# Altium schematic sheet MCU.SchDoc(MCU)
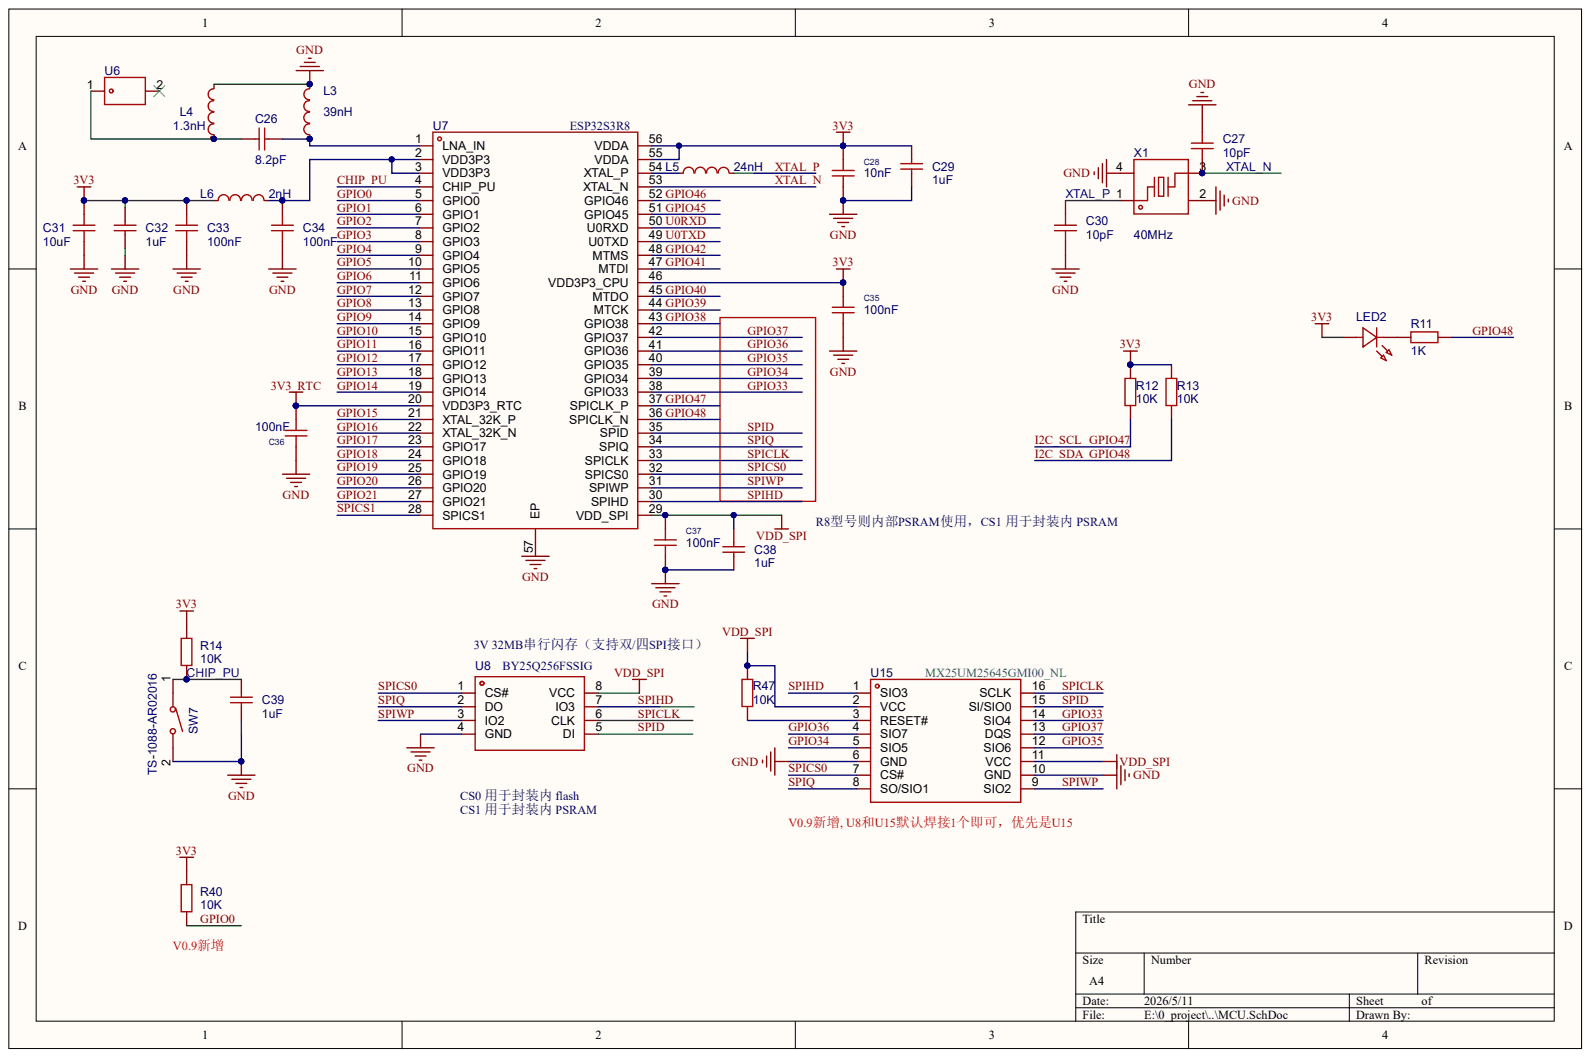
[diagram p0: MCU.SchDoc]

## Parts
C26  8.2pF
C27  10pF
C28  value 10nF  (hidden comment: 100nF)
C29  1uF
C30  10pF
C31  10uF
C32  1uF
C33  100nF
C34  100nF
C35  100nF
C36  100nF
C37  100nF
C38  1uF
C39  1uF
L3  39nH
L4  1.3nH
L5  24nH
L6  2nH
LED2  XL-1005UGC  (value not drawn)
R11  1K
R12  10K
R13  10K
R14  10K
R40  10K
R47  10K
SW7  TS-1088-AR02016
U6  RFANT5220110A0T  (value not drawn)
U7  ESP32S3R8
U8  BY25Q256FSSIG
U15  MX25UM25645GMI00_NL
X1  40MHz

## Nets
3V3: C28.2 C29.2 C31.2 C32.2 C33.2 C35.2 L6.1 LED2.2 R12.2 R13.2 R14.2 R40.2 U7.46(VDD3P3_CPU) U7.55(VDDA) U7.56(VDDA)
3V3_RTC: C36.2 U7.20(VDD3P3_RTC)
GND: C27.2 C28.1 C29.1 C30.1 C31.1 C32.1 C33.1 C34.1 C35.1 C36.1 C37.1 C38.1 C39.1 L3.2 L4.2 SW7.2 U7.57(EP) U8.4(GND) U15.6(GND) U15.10(GND) X1.2 X1.4
VDD_SPI: C37.2 C38.2 R47.2 U7.29(VDD_SPI) U8.8(VCC) U15.2(VCC) U15.11(VCC)
CHIP_PU: C39.2 R14.1 SW7.1 U7.4(CHIP_PU)
GPIO0: R40.1 U7.5(GPIO0)
GPIO1: U7.6(GPIO1)
GPIO2: U7.7(GPIO2)
GPIO3: U7.8(GPIO3)
GPIO4: U7.9(GPIO4)
GPIO5: U7.10(GPIO5)
GPIO6: U7.11(GPIO6)
GPIO7: U7.12(GPIO7)
GPIO8: U7.13(GPIO8)
GPIO9: U7.14(GPIO9)
GPIO10: U7.15(GPIO10)
GPIO11: U7.16(GPIO11)
GPIO12: U7.17(GPIO12)
GPIO13: U7.18(GPIO13)
GPIO14: U7.19(GPIO14)
GPIO15: U7.21(XTAL_32K_P)
GPIO16: U7.22(XTAL_32K_N)
GPIO17: U7.23(GPIO17)
GPIO18: U7.24(GPIO18)
GPIO19: U7.25(GPIO19)
GPIO20: U7.26(GPIO20)
GPIO21: U7.27(GPIO21)
GPIO33: U7.38(GPIO33) U15.14(SIO4)
GPIO34: U7.39(GPIO34) U15.5(SIO5)
GPIO35: U7.40(GPIO35) U15.12(SIO6)
GPIO36: U7.41(GPIO36) U15.4(SIO7)
GPIO37: U7.42(GPIO37) U15.13(DQS)
GPIO38: U7.43(GPIO38)
GPIO39: U7.44(MTCK)
GPIO40: U7.45(MTDO)
GPIO41: U7.47(MTDI)
GPIO42: U7.48(MTMS)
GPIO45: U7.51(GPIO45)
GPIO46: U7.52(GPIO46)
GPIO47: R12.1 U7.37(SPICLK_P)
GPIO48: R11.2 R13.1 U7.36(SPICLK_N)
SPICLK: U7.33(SPICLK) U8.6(CLK) U15.16(SCLK)
SPICS0: U7.32(SPICS0) U8.1(CS#) U15.7(CS#)
SPICS1: U7.28(SPICS1)
SPID: U7.35(SPID) U8.5(DI) U15.15(SI/SIO0)
SPIHD: U7.30(SPIHD) U8.7(IO3) U15.1(SIO3)
SPIQ: U7.34(SPIQ) U8.2(DO) U15.8(SO/SIO1)
SPIWP: U7.31(SPIWP) U8.3(IO2) U15.9(SIO2)
U0RXD: U7.50(U0RXD)
U0TXD: U7.49(U0TXD)
XTAL_N: C27.1 U7.53(XTAL_N) X1.3
XTAL_P: C30.2 L5.2 X1.1
NetC26_1: C26.1 L4.1 U6.1
NetC26_2: C26.2 L3.1 U7.1(LNA_IN)
NetC34_2: C34.2 L6.2 U7.2(VDD3P3) U7.3(VDD3P3)
NetL5_1: L5.1 U7.54(XTAL_P)
NetLED2_1: LED2.1 R11.1
NetR47_1: R47.1 U15.3(RESET#)

Not connected: U6 2

## Library data of the parts
C26 = (no symbol name): Symbol: 0402CG8R2C500NT; AddInBom: yes; ModelId: 36a51122f27c49738f86b3bfa98863e0; ModelName: C0402_L1.0-W0.5-H0.5; ModelTransform: 39.37,19.685,0,0,0,0,0,0,0; Voltage Rated: 50V; Temperature Coefficient: C0G; Value: 8.2pF; Device: 0402CG8R2C500NT; Supplier: LCSC; Manufacturer Part: 0402CG8R2C500NT; Supplier Part: C1579; Supplier Footprint: 0402; Datasheet: https://atta.szlcsc.com/upload/public/pdf/source/20200305/C486355_26A10D38EF8C2A5C37091D0039909270.pdf; ConvertToPcb: yes; FootprintName: R0402
C27 C30 = (no symbol name): Device: CL05C100JB5NNNC; AddInBom: yes; ModelId: 36a51122f27c49738f86b3bfa98863e0; ModelName: C0402_L1.0-W0.5-H0.5; ModelTransform: 39.37,19.685,0,0,0,0,0,0,0; Voltage Rated: 50V; Temperature Coefficient: C0G; Value: 10pF; Symbol: CL05C100JB5NNNC; SupplierPart: C32949; ManufacturerPart: CL05C100JB5NNNC; Supplier Footprint: 0402; JLCPCB Part Class: Basic Part; Datasheet: https://atta.szlcsc.com/upload/public/pdf/source/20160103/1457707722238.pdf; Supplier: LCSC; ConvertToPcb: yes; FootprintName: R0402
C28 C33-C37 = (no symbol name): Symbol: GRM155R71H104KE14D; AddInBom: yes; ModelId: 36a51122f27c49738f86b3bfa98863e0; ModelName: C0402_L1.0-W0.5-H0.5; ModelTransform: 39.37,19.685,0,0,0,0,0,0,0; Voltage Rated: 50V; Temperature Coefficient: X7R; Device: GRM155R71H104KE14D; Supplier: LCSC; Manufacturer Part: GRM155R71H104KE14D; Capacitance: 100nF; Supplier Part: C77020; Supplier Footprint: 0402; Datasheet: https://atta.szlcsc.com/upload/public/pdf/source/20180326/C77020_39BD49C951FF1AF0DB1F1FAC5AC1E401.pdf; ConvertToPcb: yes; FootprintName: R0402
  C33-C37: Value: 100nF
C29 C32 C38 C39 = (no symbol name): Device: CL05A105KP5NNNC; AddInBom: yes; ModelId: 36a51122f27c49738f86b3bfa98863e0; ModelName: C0402_L1.0-W0.5-H0.5; ModelTransform: 39.37,19.685,0,0,0,0,0,0,0; Voltage Rated: 10V; Temperature Coefficient: X5R; Value: 1uF; Symbol: CL05A105KP5NNNC; SupplierPart: C14445; ManufacturerPart: CL05A105KP5NNNC; Supplier Footprint: 0402; Datasheet: https://atta.szlcsc.com/upload/public/pdf/source/20160103/1457707670698.pdf; Supplier: LCSC; ConvertToPcb: yes; FootprintName: R0402
C31 = (no symbol name): Device: GRM155R61A106ME44D; AddInBom: yes; ModelId: 36a51122f27c49738f86b3bfa98863e0; ModelName: C0402_L1.0-W0.5-H0.5; ModelTransform: 39.37,19.685,0,0,0,0,0,0,0; Voltage Rated: 10V; Temperature Coefficient: X5R; Value: 10uF; Symbol: GRM155R61A106ME44D; SupplierPart: C77000; ManufacturerPart: GRM155R61A106ME44D; Supplier Footprint: 0402; Datasheet: https://atta.szlcsc.com/upload/public/pdf/source/20180530/C77000_4866797CFE3A842CD03C597EBD6AE6CD.pdf; Supplier: LCSC; ConvertToPcb: yes; FootprintName: R0402
L3 = (no symbol name): Symbol: SDCL1005C39NJTDF; AddInBom: yes; ModelId: d8d36575a3234d4790bfa765c88664a7; ModelName: L0402_L1.0-W0.5-H0.5_BEAD; ModelTransform: 39.37,19.685,0,0,0,0,0,0.0005,0; Rated Current: 200mA; Value: 39nH; Device: SDCL1005C39NJTDF; Supplier: LCSC; Manufacturer Part: SDCL1005C39NJTDF; Supplier Part: C26443; LCSC Part Name: 39nH; Supplier Footprint: 0402; ConvertToPcb: yes; FootprintName: R0402
L4 = (no symbol name): Symbol: SDCL1005C1N3STDF; AddInBom: yes; ModelId: f6d1952e61d54696b3449b2fc42ef9b7; ModelName: L0402-RD; ModelTransform: 40.1574,20.4724,0,0,0,0,0,0,0; Rated Current: 400mA; Q @ Freq: 8@100MHz; Frequency - Self Resonant: 10GHz; Value: 1.3nH; Device: SDCL1005C1N3STDF; Supplier: LCSC; Supplier Part: C279756; Manufacturer Part: SDCL1005C1N3STDF; LCSC Part Name: 1.3nH; Supplier Footprint: 0402; Datasheet: https://atta.szlcsc.com/upload/public/pdf/source/20231027/6126A3AAC5912C34388756BE22DEE00C.pdf; ConvertToPcb: yes; FootprintName: R0402; Tolerance: -; Saturation Current (Isat): -; Ratings: -
L5 = (no symbol name): Device: SDCL1005C24NJTDF; AddInBom: yes; ModelId: f6d1952e61d54696b3449b2fc42ef9b7; ModelName: L0402-RD; ModelTransform: 40.1574,20.4724,0,0,0,0,0,0,0; Value: 24nH; Symbol: SDCL1005C24NJTDF; SupplierPart: C74301; ManufacturerPart: SDCL1005C24NJTDF; Supplier Footprint: 0402; Datasheet: https://atta.szlcsc.com/upload/public/pdf/source/20210916/2389196E6DC4CA1121B2D3610B126F46.pdf; Supplier: LCSC; ConvertToPcb: yes; FootprintName: R0402; Rated Current: -; Saturation Current (Isat): -; DC Resistance (DCR): -; Q @ Freq: -; Frequency - Self Resonant: -; Ratings: -
L6 = (no symbol name): Manufacturer: TDK; Device: MLG1005S2N0BT000; AddInBom: yes; ModelId: d8d36575a3234d4790bfa765c88664a7; ModelName: L0402_L1.0-W0.5-H0.5_BEAD; ModelTransform: 39.37,19.685,0,0,0,0,0,0.0005,0; Rated Current: 900mA; Q @ Freq: 7@100MHz; Frequency - Self Resonant: 7.5GHz; Value: 2nH; Symbol: MLG1005S2N0BT000; SupplierPart: C76769; ManufacturerPart: MLG1005S2N0BT000; Supplier Footprint: 0402; JLCPCB Part Class: Extended Part; Datasheet: https://atta.szlcsc.com/upload/public/pdf/source/20160918/1474166917976.pdf; Supplier: LCSC; ConvertToPcb: yes; FootprintName: R0402; Tolerance: -; Saturation Current (Isat): -; Ratings: -
LED2 = (no symbol name): Symbol: XL-1005UGC_C2856703; AddInBom: yes; ModelId: 080bb9bd520f4b34ac21e218e7b036d6; ModelName: LED0402_L1.0-W0.5-H0.2; ModelTransform: 40.1574,19.685,0,0,0,0,0,-0.0005,0; Dominant Wavelength: 516nm; Peak Wavelength: 531nm; Forward Current: 25mA; Power Dissipation: 80mW; Device: XL-1005UGC; SupplierPart: C2856703; ManufacturerPart: XL-1005UGC; Supplier Footprint: 0402; JLCPCB Part Class: Extended Part; Datasheet: https://atta.szlcsc.com/upload/public/pdf/source/20221102/FF8D6BC2225363A60A01D46BB802F217.pdf; Supplier: LCSC; ConvertToPcb: yes; FootprintName: LED0402-RD_GREEN; Color Temperature: -
R11 = (no symbol name): Device: 0402WGF1502TCE; AddInBom: yes; ModelId: 671aefced39d4c318694bba8bd8efd39; ModelName: R0402_L1.0-W0.5-H0.5; ModelTransform: 39.37,19.685,0,0,0,0,0,0.0005,0; Power(Watts): 62.5mW; Overload Voltage (Max): 50V; Value: 1K; Symbol: 0402WGF1502TCE; SupplierPart: C25756; ManufacturerPart: 0402WGF1502TCE; Supplier Footprint: 0402; JLCPCB Part Class: Basic Part; Datasheet: https://atta.szlcsc.com/upload/public/pdf/source/20200306/C422600_1E6D84923E4A46A82E41ADD87F860B5C.pdf; Supplier: LCSC; ConvertToPcb: yes; FootprintName: R0402
R12-R14 R40 R47 = (no symbol name): Device: 0402WGJ0472TCE; AddInBom: yes; ModelId: 671aefced39d4c318694bba8bd8efd39; ModelName: R0402_L1.0-W0.5-H0.5; ModelTransform: 39.37,19.685,0,0,0,0,0,0.0005,0; Power(Watts): 62.5mW; Overload Voltage (Max): 50V; Value: 10K; Symbol: 0402WGJ0472TCE; SupplierPart: C25940; ManufacturerPart: 0402WGJ0472TCE; Supplier Footprint: 0402; Datasheet: https://atta.szlcsc.com/upload/public/pdf/source/20200306/C422600_1E6D84923E4A46A82E41ADD87F860B5C.pdf; Supplier: LCSC; ConvertToPcb: yes; FootprintName: R0402
SW7 = (no symbol name): AddInBom: yes; ModelId: b26698b69f274ffabef8a26c7d0e5dd1; ModelName: SW-SMD_L3.9-W2.9-H2.0-LS4.8; ModelTransform: 188.976,114.174,0,0,0,0,0,0,0; Operating Force: 160gf; Switch Length: 4mm; Switch Width: 3mm; Switch Height: 2mm; Contact Current: 50mA; Voltage Rating (DC): 12V; Symbol: TS-1088-AR02016; Device: TS-1088-AR02016; SupplierPart: C720477; ManufacturerPart: TS-1088-AR02016; Supplier Footprint: SMD; Datasheet: https://atta.szlcsc.com/upload/public/pdf/source/20200810/C720475_60D09DD6C54279ADDB2DC25100F1CC11.pdf; Supplier: LCSC; ConvertToPcb: yes; FootprintName: SW-SMD_L3.9-W3.0-P4.45
U6 = (no symbol name): AddInBom: yes; ModelId: 436e850a026743c8b178d33df3562af1; ModelName: FILTER-SMD_L5.2-W2.0-H1.2-L; ModelTransform: 205.511,79.527,0,0,0,0,0,0,0; Device: RFANT5220110A0T; Symbol: RFANT5220110A0T; LCSC Part Name: RFANT5220110A0T; SupplierPart: C127611; ManufacturerPart: RFANT5220110A0T; Supplier Footprint: SMD,2x5.2mm; Datasheet: https://atta.szlcsc.com/upload/public/pdf/source/20180326/C127611_CAA3DCCF3A021118096CAD99FC50242A.pdf; Supplier: LCSC; ConvertToPcb: yes; FootprintName: FILTER-SMD_L5.2-W2.0-L
U7 = (no symbol name): Symbol: ESP32-S3
U8 = (no symbol name): Symbol: W25Q128JVSIQTR
X1 = (no symbol name): Device: S2240000101050JY; AddInBom: yes; ModelId: d23e0abc26e54c15b6292fd5f43702fa; ModelName: CRYSTAL-SMD_4P-L2.5-W2.0-BL; ModelTransform: 98.425,78.74,0,0,0,0,0,0,0; Load Capacitance: 10pF; Supplier Part: C2885592; Value: 40MHz; Symbol: S2240000101050JY; ManufacturerPart: S2240000101050JY; Supplier Footprint: SMD2520-4P; Datasheet: https://atta.szlcsc.com/upload/public/pdf/source/20191012/C426971_E72B01839CFBB8D8B48FED2AC1315BE2.pdf; Supplier: LCSC; ConvertToPcb: yes; FootprintName: CRYSTAL-SMD_4P-L2.5-W2.0-BL
U15 = (no symbol name): Symbol: MX25UM25645GMI00
